# Altium schematic sheet FHJController_V5.0_Main.SchDoc(FHJController_V5.0_Main)
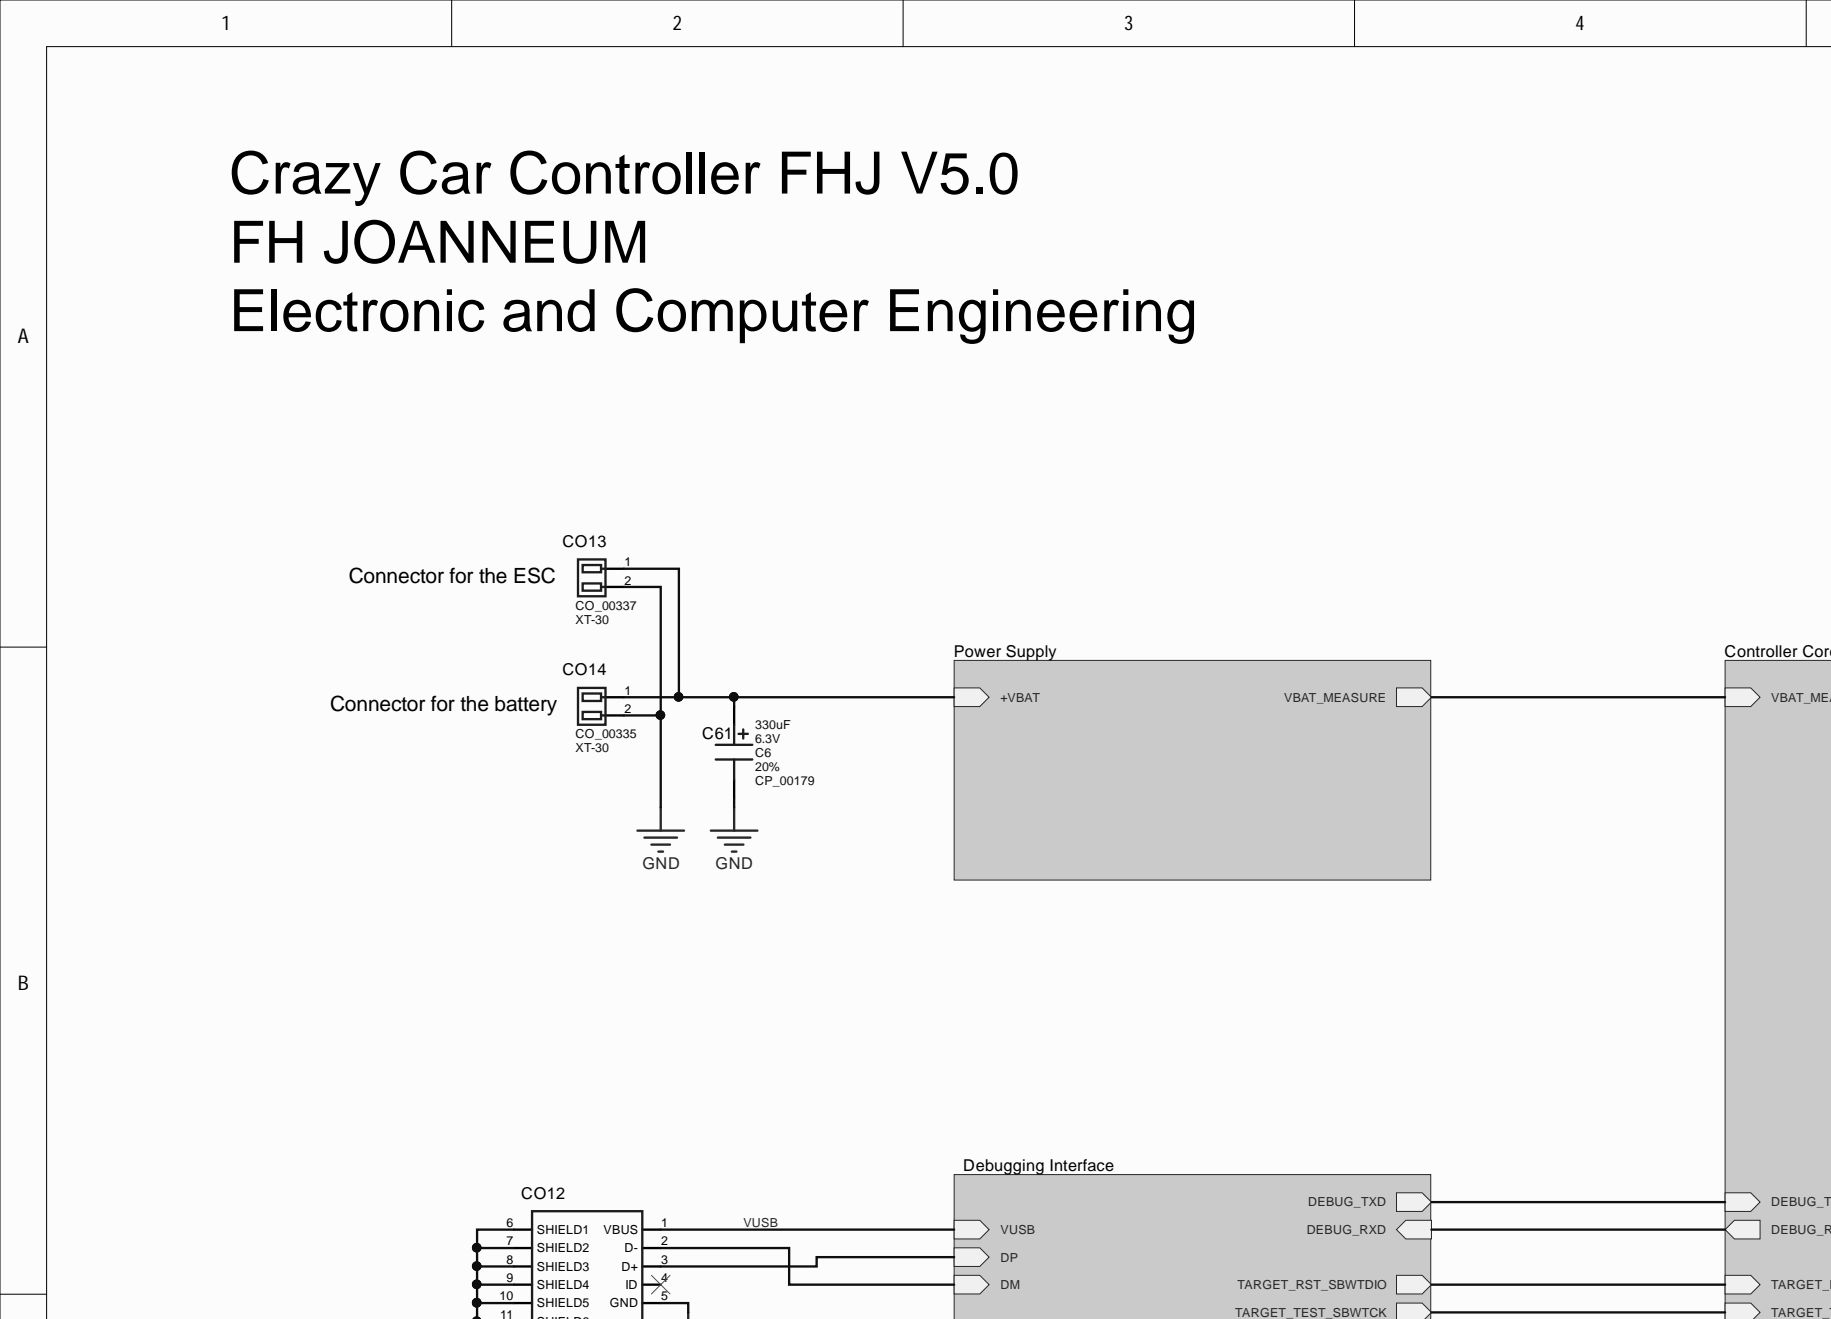
[diagram p0: FHJController_V5.0_Main.SchDoc, top left]
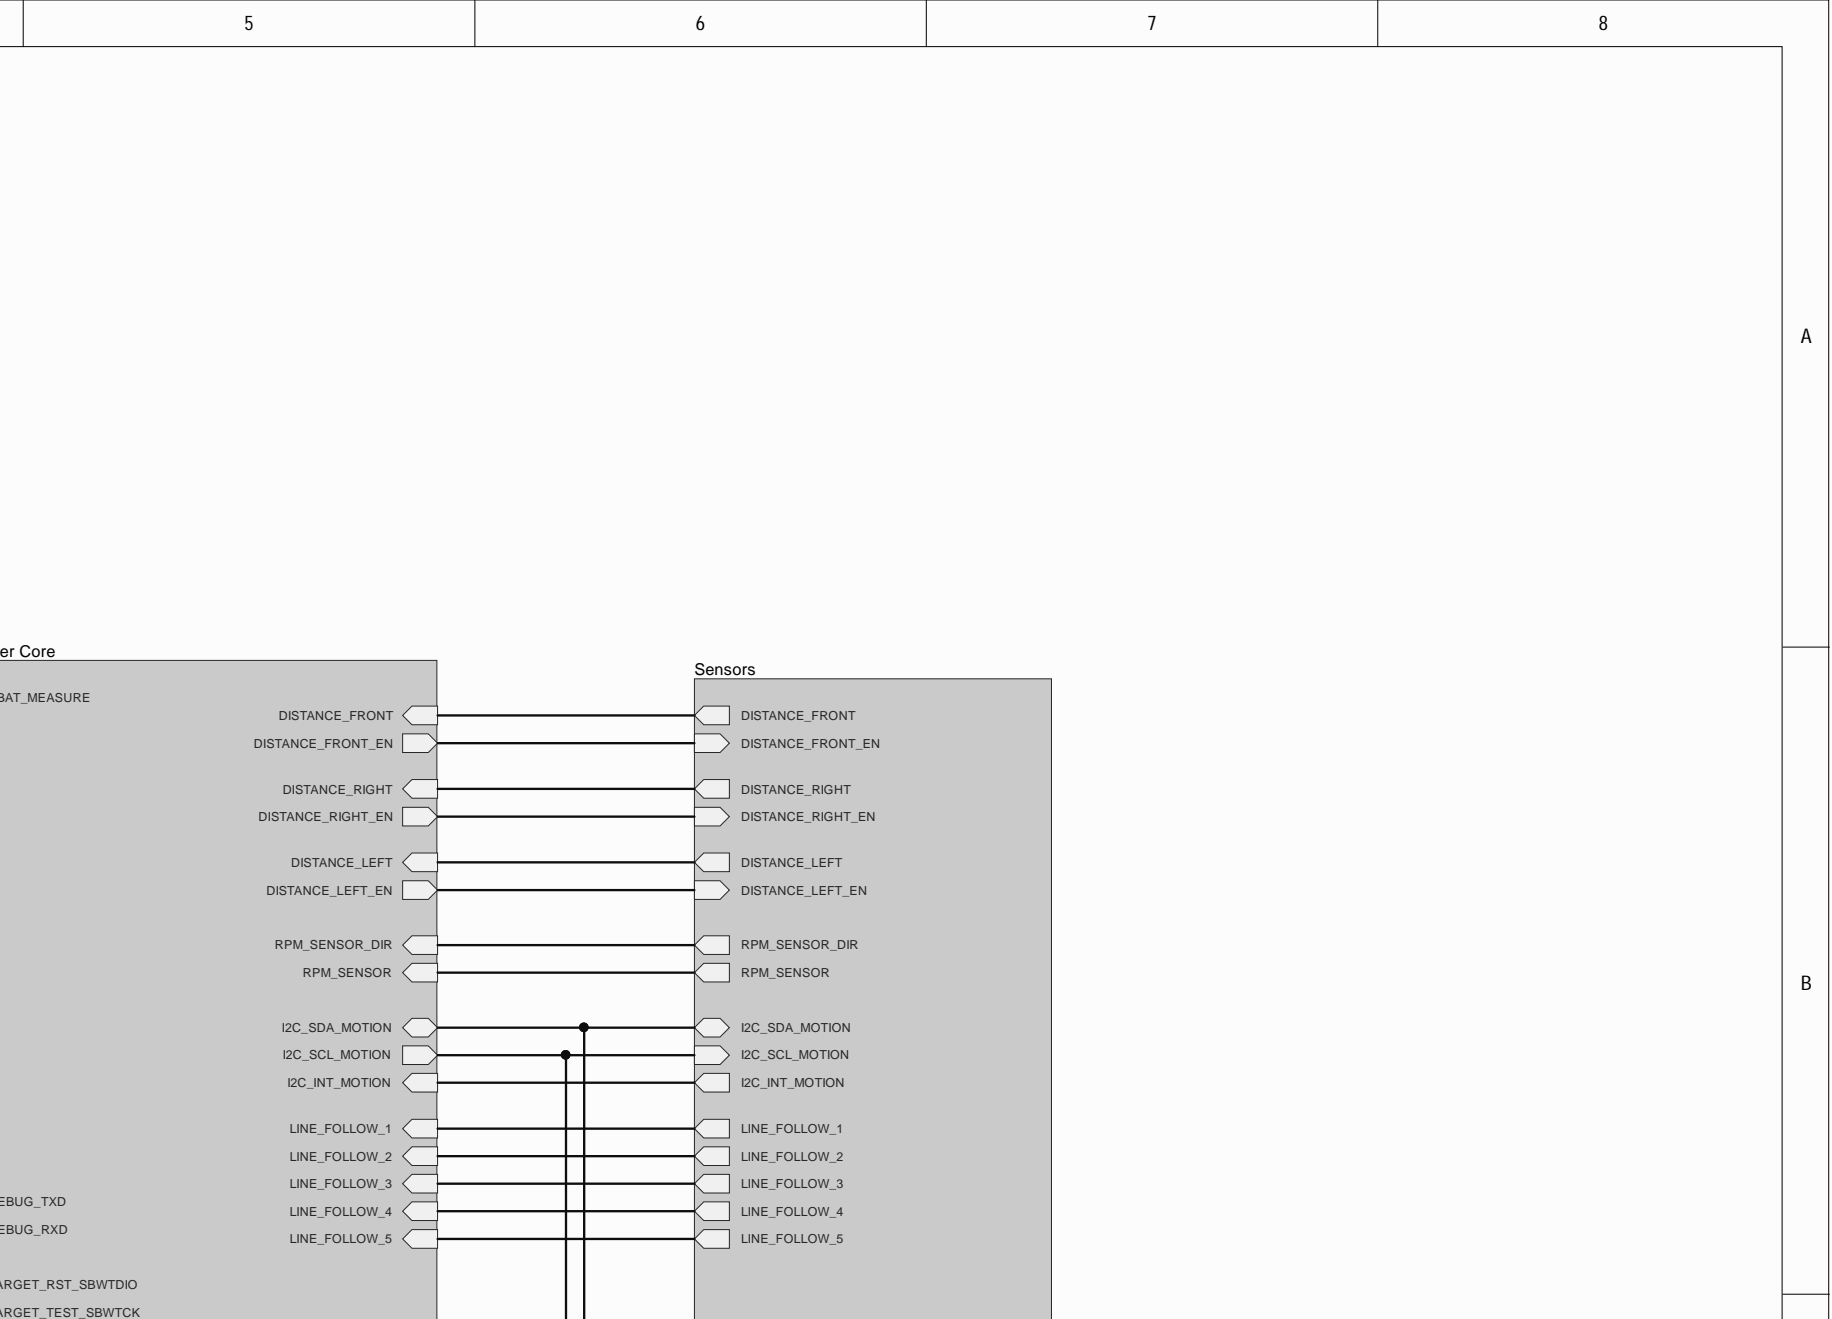
[diagram p1: FHJController_V5.0_Main.SchDoc, top right]
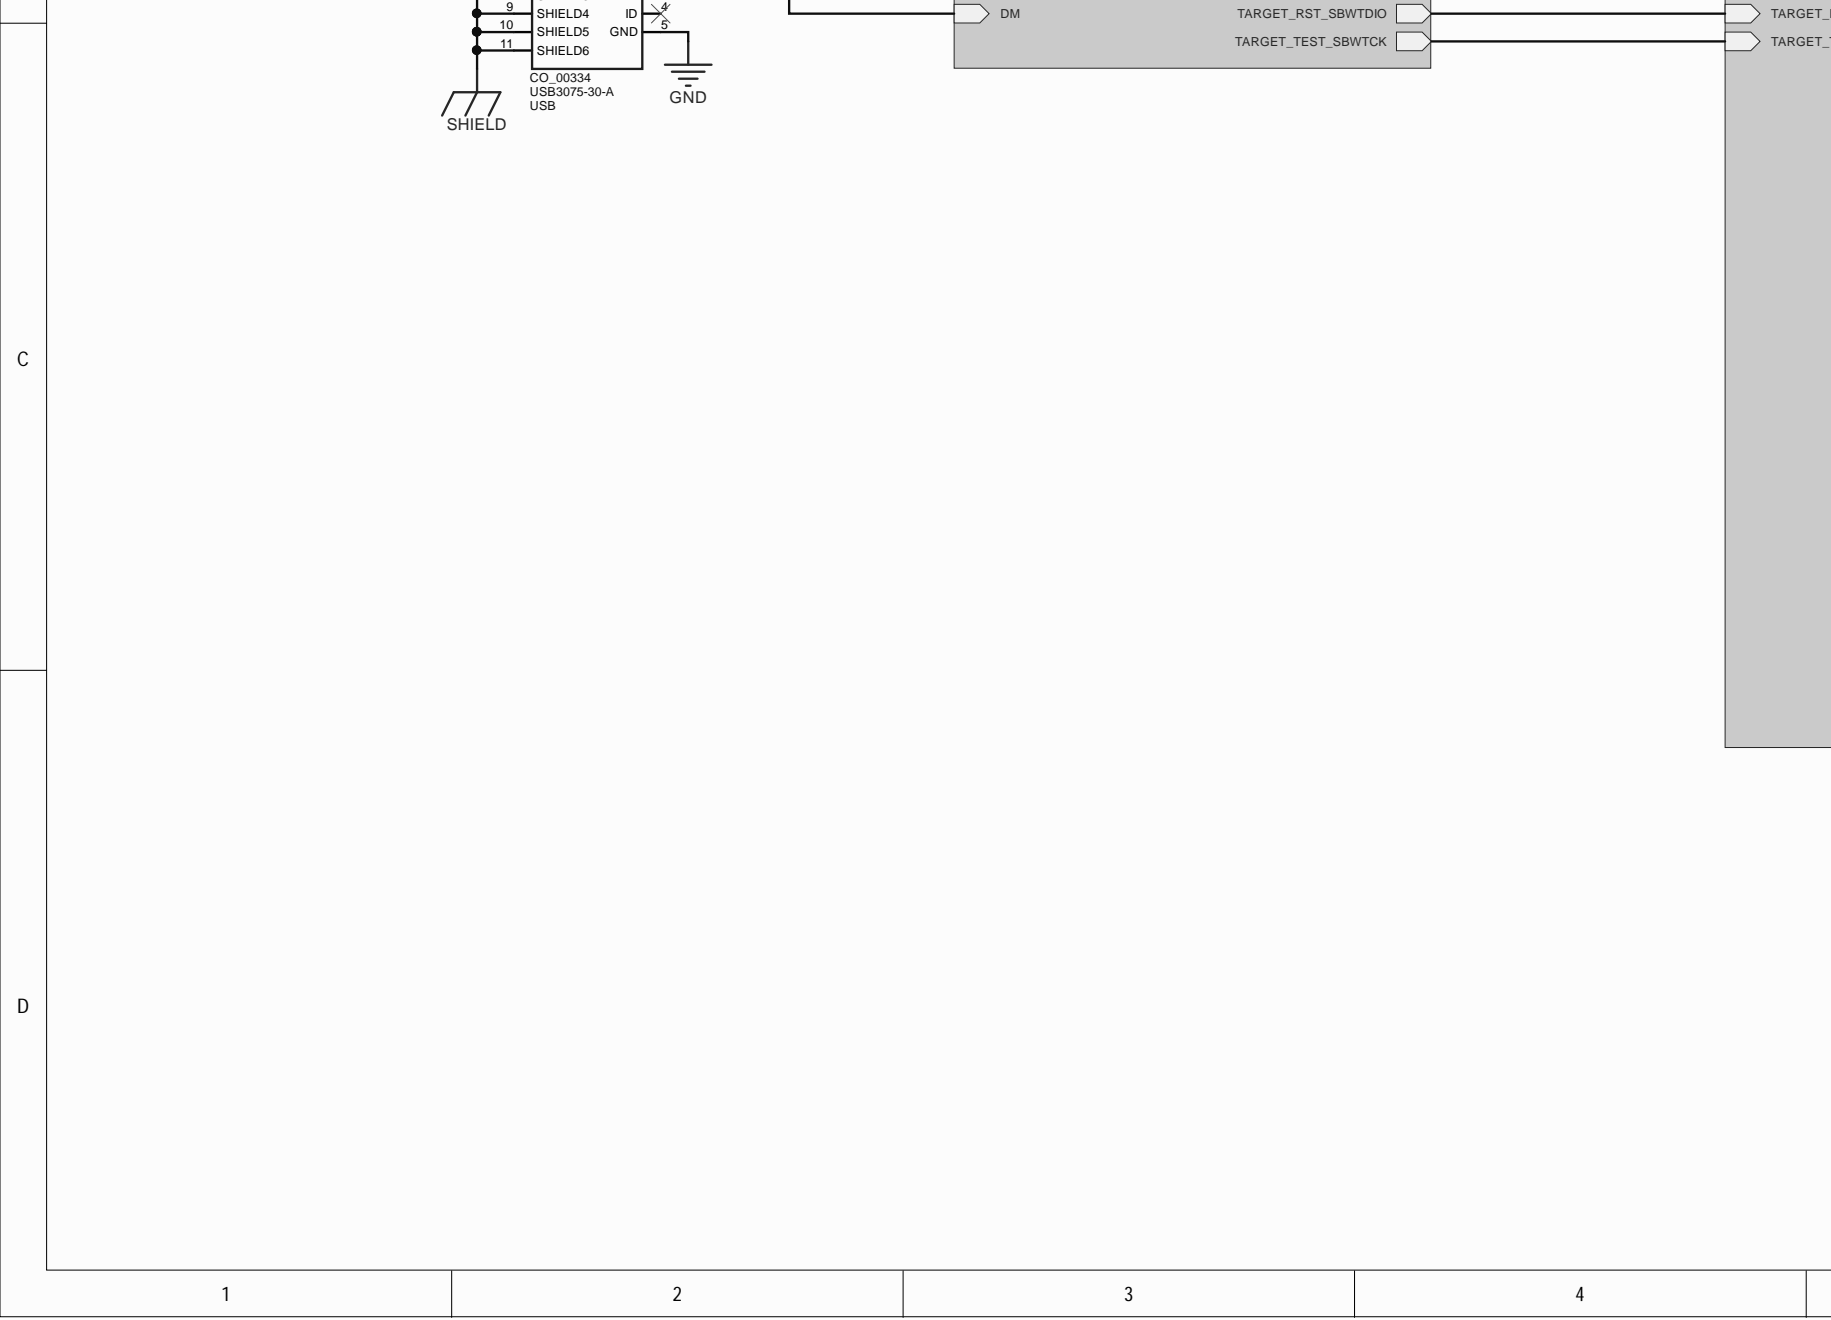
[diagram p2: FHJController_V5.0_Main.SchDoc, bottom left]
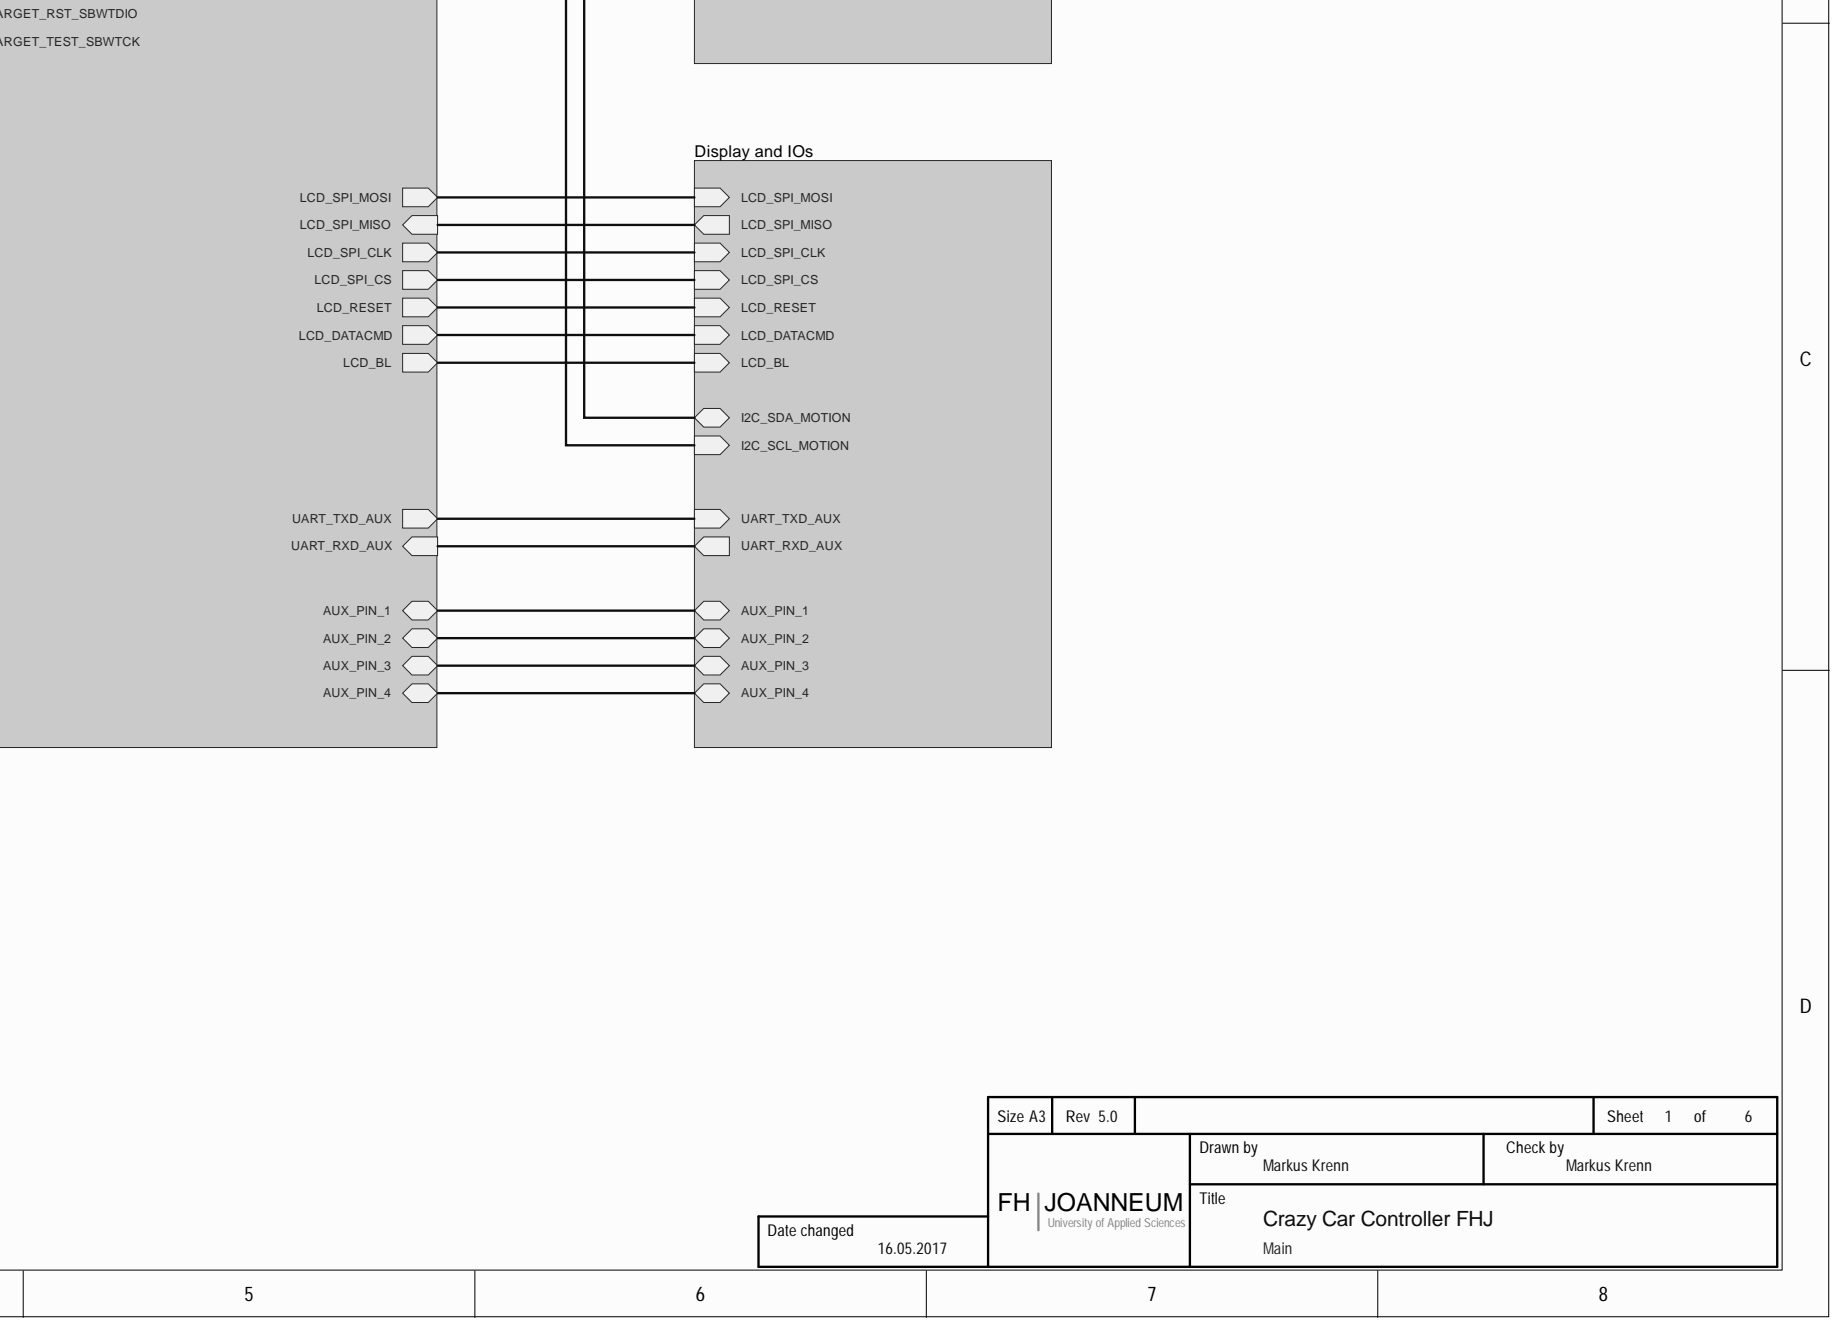
[diagram p3: FHJController_V5.0_Main.SchDoc, bottom right]

## Parts
C61  Capacitance: 330uF  Package: C6  Tolerance: 20%  Voltage: 6.3V  [CP_00179L]  (hidden comment: capacitor_polar)
CO12  Connector_Type: USB  Manufacturer_Number: USB3075-30-A  [CO_00334N]  (hidden comment: CO_00334)
CO13  Manufacturer_Number: XT-30  [CO_00337N]  (hidden comment: header1x2)
CO14  Manufacturer_Number: XT-30  [CO_00335N]  (hidden comment: header1x2)

## Nets
GND: C61.2 CO12.5(GND) CO13.2 CO14.2
SHIELD: CO12.6(SHIELD1) CO12.7(SHIELD2) CO12.8(SHIELD3) CO12.9(SHIELD4) CO12.10(SHIELD5) CO12.11(SHIELD6)
VUSB: CO12.1(VBUS)
NetC61_1: C61.1 CO13.1 CO14.1
NetCO12_2: CO12.2(D-)
NetCO12_3: CO12.3(D+)
NetCO12_4: CO12.4(ID)
AUX_PIN_1:
AUX_PIN_2:
AUX_PIN_3:
AUX_PIN_4:
DEBUG_RXD:
DEBUG_TXD:
DISTANCE_FRONT:
DISTANCE_FRONT_EN:
DISTANCE_LEFT:
DISTANCE_LEFT_EN:
DISTANCE_RIGHT:
DISTANCE_RIGHT_EN:
I2C_INT_MOTION:
I2C_SCL_MOTION:
I2C_SDA_MOTION:
LCD_BL:
LCD_DATACMD:
LCD_RESET:
LCD_SPI_CLK:
LCD_SPI_CS:
LCD_SPI_MISO:
LCD_SPI_MOSI:
LINE_FOLLOW_1:
LINE_FOLLOW_2:
LINE_FOLLOW_3:
LINE_FOLLOW_4:
LINE_FOLLOW_5:
RPM_SENSOR:
RPM_SENSOR_DIR:
TARGET_RST_SBWTDIO:
TARGET_TEST_SBWTCK:
UART_RXD_AUX:
UART_TXD_AUX:
VBAT_MEASURE:

## Library data of the parts
C61 = CP_00179: Manufacturer_Number: 875105144010; Database Table Name: altium_partlib_pol_capacitor; Dielectric_Material: Conductive Polymer Aluminum Solid; ESR: 0R02; Component Kind: Standard; Creation_Date: 13.06.2016; Datasheet_Link: http://ibi/dxdb_partdatasheet_download.php?pid=3183; Part_Number: CP_00179; Creator: Krenn Markus; Library Name: Central Library.DbLib
CO12 = CO_00334: Micro-USB Typ B, USB 2.0, Buchse, 5 -polig, Oberflächenmontage, Rechtwinklig; Connector_gender: male; Contact_coating: Gold; Contact_Count: 5; Current: 1A; Database Table Name: altium_partlib_connector; Function_type: Wire-to-Board; Impedance: 0R03; Mounting_angle: 90°; Nominal_AC_Voltage: 100VAC; Nominal_DC_Voltage: 100VDC; Power: 0W; Row_Count: 1; Component Kind: Standard; Creation_Date: 06.06.2016; Datasheet_Link: http://ibi/dxdb_partdatasheet_download.php?pid=3170; Material: Copper-Alloy; Mounting: SMD; Part_Number: CO_00334; Creator: Krenn Markus; Library Name: Central Library.DbLib
CO13 = CO_00337: DYMOND XT-30 BEC Stecker; Connector_gender: female; Connector_Type: Terminal Block; Contact_coating: Gold; Contact_Count: 2; Current: 5A; Database Table Name: altium_partlib_connector; Function_type: Wire-to-Board; Impedance: 0R; Mounting_angle: 0°; Nominal_AC_Voltage: 0VAC; Nominal_DC_Voltage: 0VDC; Pitch_style: 0.005m; Power: 0W; Row_Count: 1; Component Kind: Standard; Creation_Date: 10.06.2016; Datasheet_Link: http://ibi/dxdb_partdatasheet_download.php?pid=3181; Material: Copper-Alloy; Mounting: Through Hole; Part_Number: CO_00337; Creator: Krenn Markus; Library Name: Central Library.DbLib
CO14 = CO_00335: DYMOND XT-30 BEC Stecker; Connector_gender: male; Connector_Type: Terminal Block; Contact_coating: Gold; Contact_Count: 2; Current: 5A; Database Table Name: altium_partlib_connector; Function_type: Wire-to-Board; Impedance: 0R; Mounting_angle: 0°; Nominal_AC_Voltage: 0VAC; Nominal_DC_Voltage: 0VDC; Pitch_style: 0.005m; Power: 0W; Row_Count: 1; Component Kind: Standard; Creation_Date: 07.06.2016; Datasheet_Link: http://ibi/dxdb_partdatasheet_download.php?pid=3173; Material: Copper-Alloy; Mounting: Through Hole; Part_Number: CO_00335; Creator: Krenn Markus; Library Name: Central Library.DbLib
